# Altium schematic sheet UserInterface.SchDoc(UserInterface)
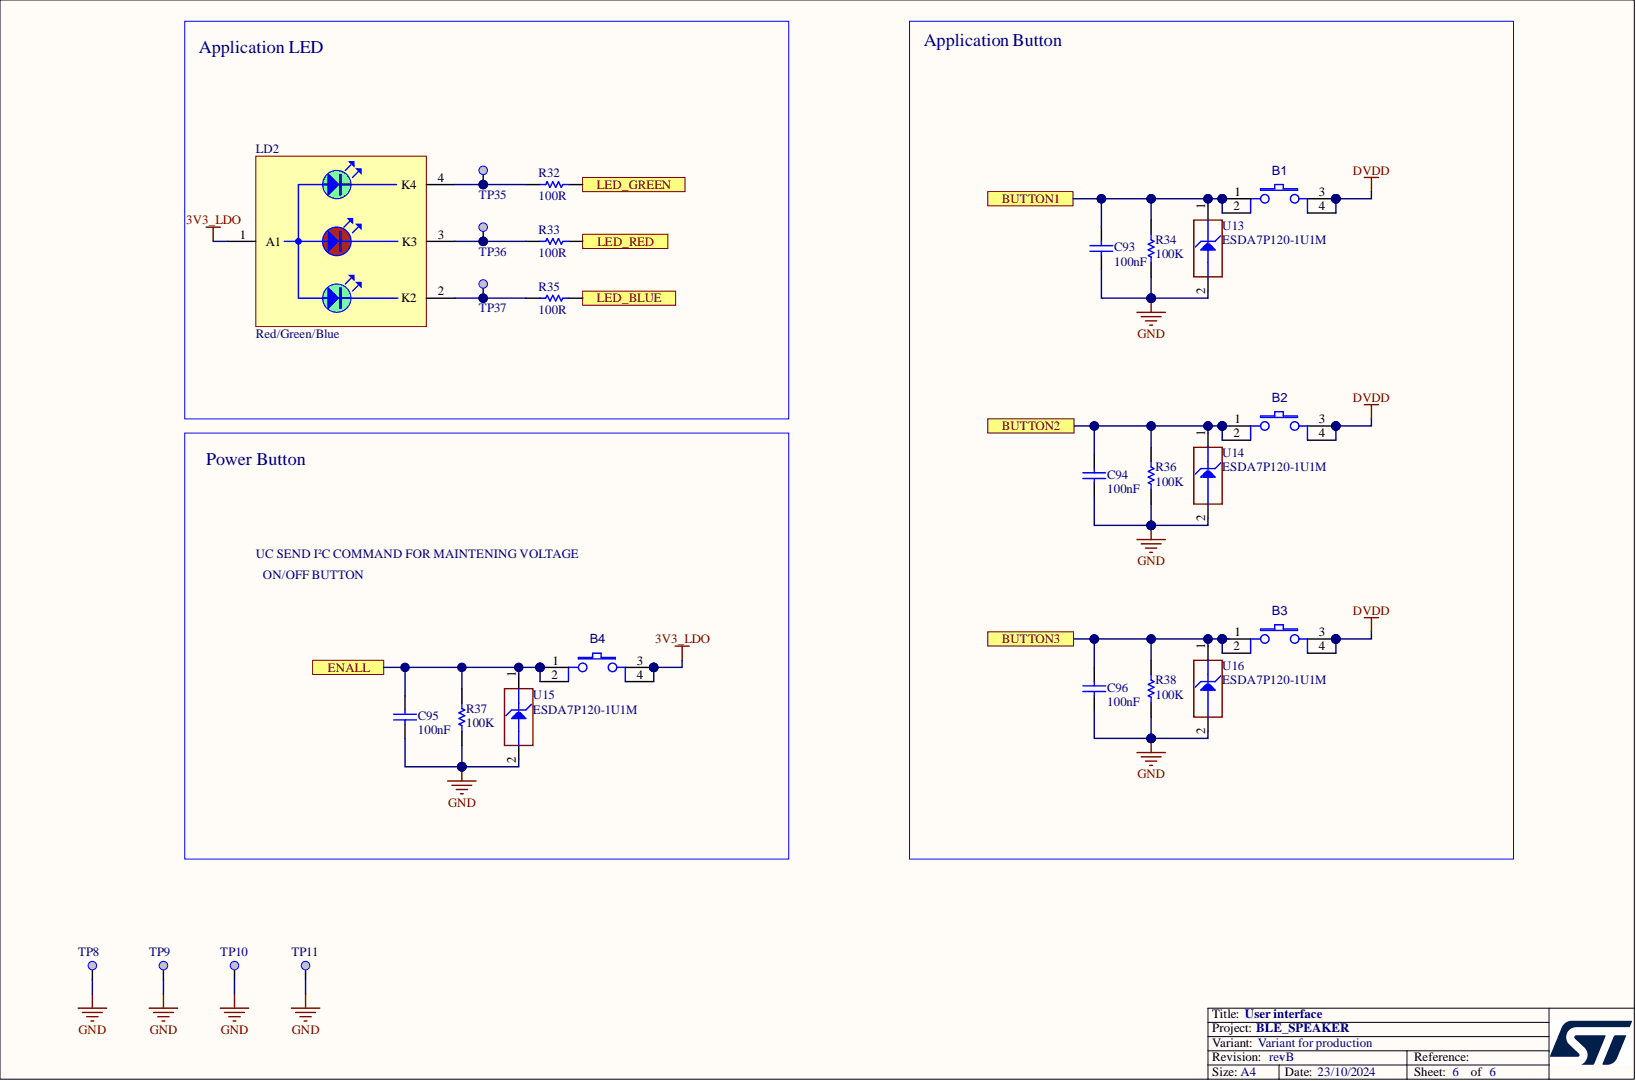
[diagram p0: UserInterface.SchDoc]

## Parts
B1  SW_PUSH_SMD  [SWI_APEM_ADTSM644KV_BLACK]  (value not drawn)
B2  SW_PUSH_SMD  [SWI_APEM_ADTSM644KV_BLACK]  (value not drawn)
B3  SW_PUSH_SMD  [SWI_APEM_ADTSM644KV_BLACK]  (value not drawn)
B4  SW_PUSH_SMD  [SWI_APEM_ADTSM644KV_BLACK]  (value not drawn)
C93  value 100nF  [C0201_H0P3]  (hidden comment: Capacitor)
C94  value 100nF  [C0201_H0P3]  (hidden comment: Capacitor)
C95  value 100nF  [C0201_H0P3]  (hidden comment: Capacitor)
C96  value 100nF  [C0201_H0P3]  (hidden comment: Capacitor)
LD2  value Red/Green/Blue  [LED_TRI-RGB_WURTH_150141M173100]  (hidden comment: LED_RGB)
R32  value 100R  [R0402]  (hidden comment: Resistor)
R33  value 100R  [R0402]  (hidden comment: Resistor)
R34  value 100K  [R0402]  (hidden comment: Resistor)
R35  value 100R  [R0402]  (hidden comment: Resistor)
R36  value 100K  [R0402]  (hidden comment: Resistor)
R37  value 100K  [R0402]  (hidden comment: Resistor)
R38  value 100K  [R0402]  (hidden comment: Resistor)
TP8  Test Point  [TP_SMD_1MM]  (value not drawn)  (no BOM)
TP9  Test Point  [TP_SMD_1MM]  (value not drawn)  (no BOM)
TP10  Test Point  [TP_SMD_1MM]  (value not drawn)  (no BOM)
TP11  Test Point  [TP_SMD_1MM]  (value not drawn)  (no BOM)
TP35  Test Point  [TP_SMD_1MM]  (value not drawn)  (no BOM)
TP36  Test Point  [TP_SMD_1MM]  (value not drawn)  (no BOM)
TP37  Test Point  [TP_SMD_1MM]  (value not drawn)  (no BOM)
U13  value ESDA7P120-1U1M  Manufacturer_Part_Number: ESDA7P120-1U1M  [ESD_QFN1610_2N_1K_2A]  (hidden comment: ESD-TRANSIL)
U14  value ESDA7P120-1U1M  Manufacturer_Part_Number: ESDA7P120-1U1M  [ESD_QFN1610_2N_1K_2A]  (hidden comment: ESD-TRANSIL)
U15  value ESDA7P120-1U1M  Manufacturer_Part_Number: ESDA7P120-1U1M  [ESD_QFN1610_2N_1K_2A]  (hidden comment: ESD-TRANSIL)
U16  value ESDA7P120-1U1M  Manufacturer_Part_Number: ESDA7P120-1U1M  [ESD_QFN1610_2N_1K_2A]  (hidden comment: ESD-TRANSIL)

## Ports
BUTTON1, BUTTON2, BUTTON3, ENALL, LED_BLUE, LED_GREEN, LED_RED

## Nets
3V3_LDO: B4.3 B4.4 LD2.1(A1)
DVDD: B1.3 B1.4 B2.3 B2.4 B3.3 B3.4
GND: C93.2 C94.2 C95.2 C96.2 R34.1 R36.1 R37.1 R38.1 TP8.1 TP9.1 TP10.1 TP11.1 U13.2 U14.2 U15.2 U16.2
BUTTON1: B1.1 B1.2 C93.1 R34.2 U13.1 ; port BUTTON1
BUTTON2: B2.1 B2.2 C94.1 R36.2 U14.1 ; port BUTTON2
BUTTON3: B3.1 B3.2 C96.1 R38.2 U16.1 ; port BUTTON3
ENALL: B4.1 B4.2 C95.1 R37.2 U15.1 ; port ENALL
LED_BLUE: R35.1 ; port LED_BLUE
LED_GREEN: R32.1 ; port LED_GREEN
LED_RED: R33.2 ; port LED_RED
NetLD2_2: LD2.2(K2) R35.2 TP37.1
NetLD2_3: LD2.3(K3) R33.1 TP36.1
NetLD2_4: LD2.4(K4) R32.2 TP35.1

## Library data of the parts
B1-B4 = MCD-02524: Push Button, NO, 0.05A, 12V; Manufacturer: WURTH ELECTRONIK; Manufacturer_Part_Number: 430182070816; Part_Preference: Recommended; Part_Type: Misc; Partial_Alternate_Internal_Part_Number: MCD-03303; Component_Country_Of_Origin: Unknown; Database Table Name: MCD_PARTS$; ID: 4630; ShowFields: YES; Value: 430182070816; Component Kind: Standard; ComponentLink1URL: http://tunmcdsvn.tun.st.com/Component_Documentation_Library/Trunk/Misc/APEM_ADTS-AKTSC_Series; Internal_Part_Number: MCD-02524; Library Name: MCD_PARTS_database.DbLib; Manufacturer_HQ_COO: Unknown; Order_Code_Farnell: N/A; Order_Code_RS: N/A; Package: 6,2x6,2mm; Status: Checked
C93-C96 = MCD-00697: Capacitor, MLCC, 100nF,10V, X5R, +/-10%, 0201, T=0.3mm; Manufacturer: TDK; Manufacturer_Part_Number: C0603X5R1A104K030BC; Part_Preference: Recommended; Component_Country_Of_Origin: Unknown; Database Table Name: MCD_PARTS$; ID: 3046; ShowFields: YES; Component Kind: Standard; ComponentLink1URL: http://tunmcdsvn.tun.st.com/Component_Documentation_Library/Trunk/Capacitor/TDK_MCC_Series; Internal_Part_Number: MCD-00697; Library Name: MCD_PARTS_database.DbLib; Order_Code_Digikey: 445-7318-1-ND; Order_Code_Farnell: 2524957; Order_Code_RS: N/A; Package: 0201; Part_Type: Capacitor; Status: Released
LD2 = MCD-02035: LED Multicolor, Red/Green/Blue, 2V/3V2/3V2, 20mA, 2.8x3.2mm; Manufacturer: WURTH ELEKTRONIK; Manufacturer_Part_Number: 150141M173100; Part_Preference: Recommended; Part_Type: Display; Component_Country_Of_Origin: Unknown; Database Table Name: MCD_PARTS$; ID: 4135; Order_Code_RS: 146-0091; ShowFields: YES; Component Kind: Standard; ComponentLink1URL: http://tunmcdsvn.tun.st.com/Component_Documentation_Library/Trunk/Display/WURTH_ELEKTRONIK_150141M173100; Internal_Part_Number: MCD-02035; Library Name: MCD_PARTS_database.DbLib; Order_Code_Digikey: 732-4998-2-ND; Order_Code_Farnell: N/A; Package: 2.8x3.2mm; Status: Released
R32 R33 R35 = MCD-00119: Resistor, 100R, 0402, +/-1%, 1/16W; Manufacturer: YAGEO; Manufacturer_Part_Number: RC0402FR-07100RL; Part_Preference: Not recommended; Component_Country_Of_Origin: China; Database Table Name: MCD_PARTS$; ID: 2748; ShowFields: YES; Component Kind: Standard; ComponentLink1URL: http://tunmcdsvn.tun.st.com/Component_Documentation_Library/Trunk/Resistor/YAGEO_RC_L_Series; Internal_Part_Number: MCD-00119; Library Name: MCD_PARTS_database.DbLib; Order_Code_Digikey: 311-100LRCT-ND; Order_Code_Farnell: 9239111; Order_Code_RS: N/A; Package: 0402; Part_Type: Resistor; Status: Released
R34 R36-R38 = MCD-00059: Resistor, 100K, 0402, +/-1%, 1/16W; Manufacturer: YAGEO; Manufacturer_Part_Number: RC0402FR-07100KL; Part_Preference: Recommended; Partial_Alternate_Internal_Part_Number: MCD-03301; Component_Country_Of_Origin: China; Database Table Name: MCD_PARTS$; ID: 2533; Order_Code_RS: 2421891; ShowFields: YES; Component Kind: Standard; ComponentLink1URL: http://tunmcdsvn.tun.st.com/Component_Documentation_Library/Trunk/Resistor/YAGEO_RC_L_Series; Internal_Part_Number: MCD-00059; Library Name: MCD_PARTS_database.DbLib; Order_Code_Digikey: 311-100KLRTR-ND; Order_Code_Farnell: 2421891; Package: 0402; Part_Type: Resistor; Status: Released
TP8-TP11 TP35-TP37 = MCD-00074: Test Point 1mm; Part_Preference: Recommended; Part_Type: Misc; Component_Country_Of_Origin: Unknown; Database Table Name: MCD_PARTS$; ID: 2518; ShowFields: YES; ComponentLink1URL: http://tunmcdsvn.tun.st.com/Component_Documentation_Library/Trunk/Misc/; Internal_Part_Number: MCD-00074; Library Name: MCD_PARTS_database.DbLib; Manufacturer: N/A; Manufacturer_HQ_COO: Unknown; Manufacturer_Part_Number: N/A; Order_Code_Digikey: N/A; Order_Code_Farnell: N/A; Order_Code_RS: N/A; Status: Released; Value: Test Point 1mm
U13-U16 = MCD-01026: Diode, ESD TVS, 5V5, 1.5uA, 6V8, QFN1610; BMS_Part_Number: 9179046; Manufacturer: STMICROELECTRONICS; Manufacturer_HQ_COO: EU; Part_Preference: Recommended; Component_Country_Of_Origin: China; Database Table Name: MCD_PARTS$; ID: 2997; Order_Code_RS: 190-7605; ShowFields: YES; Component Kind: Standard; ComponentLink1URL: http://tunmcdsvn.tun.st.com/Component_Documentation_Library/Trunk/Diode/STMICROELECTRONICS_ESDA7P120-1U1M; Internal_Part_Number: MCD-01026; Library Name: MCD_PARTS_database.DbLib; Order_Code_Digikey: 497-17159-2-ND; Order_Code_Farnell: 3130027; Package: QFN1610; Part_Type: Diode; Status: Released
